# Altium schematic sheet Sheet1.SchDoc
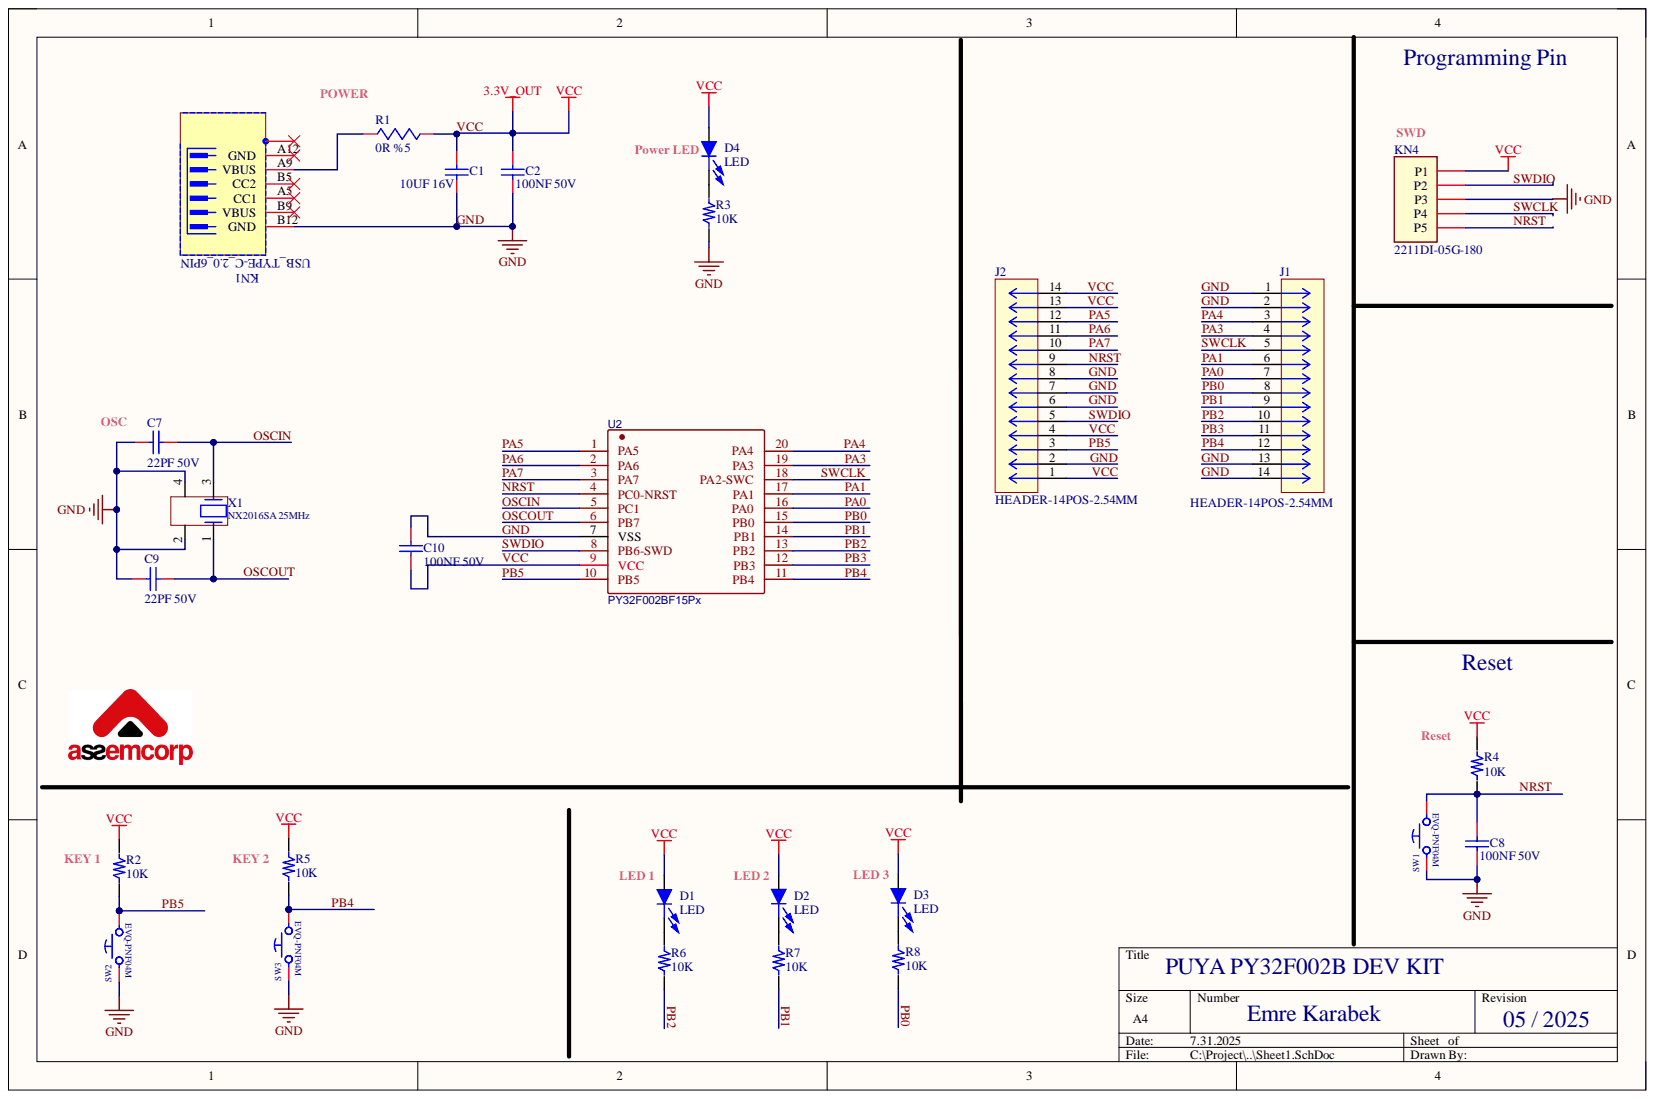
[diagram p0: Sheet1.SchDoc]

## Parts
C1  10UF 16V  [C0805]
C2  100NF 50V  [C0805]
C7  22PF 50V  [C0603]
C8  100NF 50V  [C0805]
C9  22PF 50V  [C0603]
C10  100NF 50V  [C0805]
D1  LED  [LED-0805]
D2  LED  [LED-0805]
D3  LED  [LED-0805]
D4  LED  [LED-0805]
J1  HEADER-14POS-2.54MM  [14POS-2.54MM]
J2  HEADER-14POS-2.54MM  [14POS-2.54MM]
KN1  USB_TYPE-C_2.0_6PIN  [USB_TYPE-C_2.0]
KN4  2211DI-05G-180  [87937_5]
R1  0R %5  [R0805]
R2  10K  [R0603]
R3  10K  [R0603]
R4  10K  [R0603]
R5  10K  [R0603]
R6  10K  [R0603]
R7  10K  [R0603]
R8  10K  [R0603]
SW1  EVQ-PNF04M  [EVQ-PNF04M]
SW2  EVQ-PNF04M  [EVQ-PNF04M]
SW3  EVQ-PNF04M  [EVQ-PNF04M]
U2  PY32F002BF15Px  [TSSOP-20]
X1  value NX2016SA 25MHz  Package: NX2016SA  [NX2016SA-25.000M-STD-CZS-1]  (hidden comment: XTAL_4_PINS)

## Nets
GND: C1.2 C2.1 C7.2 C8.1 C9.2 C10.2 J1.1 J1.2 J1.13 J1.14 J2.2 J2.6 J2.7 J2.8 KN1.B12(GND) KN4.3(P3) R3.1 SW1.1 SW2.1 SW3.1 U2.7(VSS) X1.2 X1.4
NRST: C8.2 J2.9 KN4.5(P5) R4.1 SW1.2 U2.4(PC0-NRST)
OSCIN: C7.1 U2.5(PC1) X1.3
OSCOUT: C9.1 U2.6(PB7) X1.1
PA0: J1.7 U2.16(PA0)
PA1: J1.6 U2.17(PA1)
PA3: J1.4 U2.19(PA3)
PA4: J1.3 U2.20(PA4)
PA5: J2.12 U2.1(PA5)
PA6: J2.11 U2.2(PA6)
PA7: J2.10 U2.3(PA7)
PB0: J1.8 R8.1 U2.15(PB0)
PB1: J1.9 R7.1 U2.14(PB1)
PB2: J1.10 R6.1 U2.13(PB2)
PB3: J1.11 U2.12(PB3)
PB4: J1.12 R5.1 SW3.2 U2.11(PB4)
PB5: J2.3 R2.1 SW2.2 U2.10(PB5)
SWCLK: J1.5 KN4.4(P4) U2.18(PA2-SWC)
SWDIO: J2.5 KN4.2(P2) U2.8(PB6-SWD)
VCC: C1.1 C2.2 C10.1 D1.2 D2.2 D3.2 D4.2 J2.1 J2.4 J2.13 J2.14 KN4.1(P1) R1.2 R2.2 R4.2 R5.2 U2.9(VCC)
NetD1_1: D1.1 R6.2
NetD2_1: D2.1 R7.2
NetD3_1: D3.1 R8.2
NetD4_1: D4.1 R3.2
NetKN1_A9: KN1.A9(VBUS) R1.1

Not connected: KN1 A5(CC1) A12(GND) B5(CC2) B9(VBUS) TAB

## Library data of the parts
C1 = C0805 10UF 16V %10 X7R: Database Table Name: Capacitor SMD; Defined by: MK; Malzeme Tanımı: CAP 0805 CER 10UF 16V %10 X7R; SapStokKodu: 1200920687; Siparis Kodu: CL21B106KOQNNNE; Component Kind: Standard; Part Number: C0805 10UF 16V %10 X7R; Value: 10UF 16V; Library Name: Emre.DbLib
C2 C8 C10 = C0805 100NF 50V %10 X7R: Database Table Name: Capacitor SMD; Malzeme Tanımı: CAP 0805 CER 100n 50V%10 X7R; SapStokKodu: 1200920082; Siparis Kodu: VJ0805Y104KXACW1BC; Component Kind: Standard; Part Number: C0805 100NF 50V %10 X7R; Value: 100NF 50V; Library Name: Emre.DbLib
C7 C9 = C0603 22PF 50V %5 NPO: Database Table Name: Capacitor SMD; Malzeme Tanımı: CAP 0603 CER 22p 50V%5 NP0; SapStokKodu: 1200920404; Siparis Kodu: 0603CG220J500NT; Component Kind: Standard; Part Number: C0603 22PF 50V %5 NPO; Value: 22PF 50V; Library Name: Emre.DbLib
D1-D4 = LED_0805: PCB DECAL: 0805; Component Kind: Standard; Library Name: ZqfAdLibrary.SchLib
J1 J2 = HEADER-14POS-2.54MM: 14 POS - 2.54MM HEADER; Manufacturer_Part_Number: 61300211121; Mfr_Name: Wurth Electronics; Component Kind: Standard; Library Name: Connectors-Header.SchLib; RefDes: RefDes; Type: DEV; Copyright: Copyright (C) 2024 Ultra Librarian. All rights reserved.
KN1 = USB_TYPE-C_2.0_6PIN: Database Table Name: Connector; Defined by: MK; Malzeme Tanımı: KONN SM USB TYPE C USB2.0 6PIN; SAPStokKodu: 1200951559; Siparis Kodu: 2171750001; Uretici: Molex; Component Kind: Standard; Datasheet Link: https://www.molex.com/pdm_docs/sd/2171750001_sd.pdf; Part Number: USB_TYPE-C_2.0_6PIN; Value: USB_TYPE-C_2.0_6PIN; Library Name: Emre.DbLib
KN4 = 2211DI-05G-180: Database Table Name: Connector; Component Kind: Standard; Part Number: 2211DI-05G-180; Value: 2211DI-05G-180; Library Name: Emre.DbLib
R1 = R0805 0R %5 1/8W: Database Table Name: Resistor SMD; Malzeme Tanımı: RES 0805 0R %5; SapStokKodu: 1200970116; Siparis Kodu: WR08X000 PTL; Component Kind: Standard; Part Number: R0805 0R %5 1/8W; Value: 0R %5; Library Name: Emre.DbLib
R2-R8 = R0603: PCB DECAL: 0603; Component Kind: Standard; Library Name: ZqfAdLibrary.SchLib
SW1-SW3 = EVQ-PNF04M: Database Table Name: Misc; Malzeme Tanımı: ANAH. SM TACT SPST 160GF 12V 50mA; SAPStokKodu: 1200510001; Component Kind: Standard; Part Number: EVQ-PNF04M; Siparis Kodu: EVQ-PNF04M; Value: EVQ-PNF04M; Library Name: Emre.DbLib
U2 = PY32F002BF15Px: TSSOP20; BOM_Manufacturer: PUYA(普冉); BOM_Manufacturer Part: PY32F002BF15Px; Component Kind: Standard; Library Name: Puya-MCU_V1.0.SchLib; spicePre: U; spiceSymbolName: PY32F002BF15Px
X1 = NX2016SA-25.000M-STD-CZS-1: Crystals CRYSTAL 25MHZ 8PF SMD; BMS_Part_Number: 9157044; Manufacturer: NDK; Manufacturer_Part_Number: NX2016SA 25MHz EXS00A-CS11321; Part_Type: Crystal_Osc; Internal_Part_Number: MCD-01364; ECCN_EU: NEC; ECCN_US: EAR99; Provided_By: SUBCO; Component Kind: Standard; ComponentLink1Description: Datasheet; ComponentLink1URL: http://tunmcdsvn.tun.st.com/Component_Datasheet_Library/Trunk/Crystal_Osc/NDK_NX2016SA 25MHz EXS00A-CS11321 (EXS10B-28187); Order_Code_Digikey: 644-1367; Status: Released; Library Name: Deka-Crystal.IntLib
